# Altium schematic sheet 3-Fuses.SchDoc(3-Fuses)
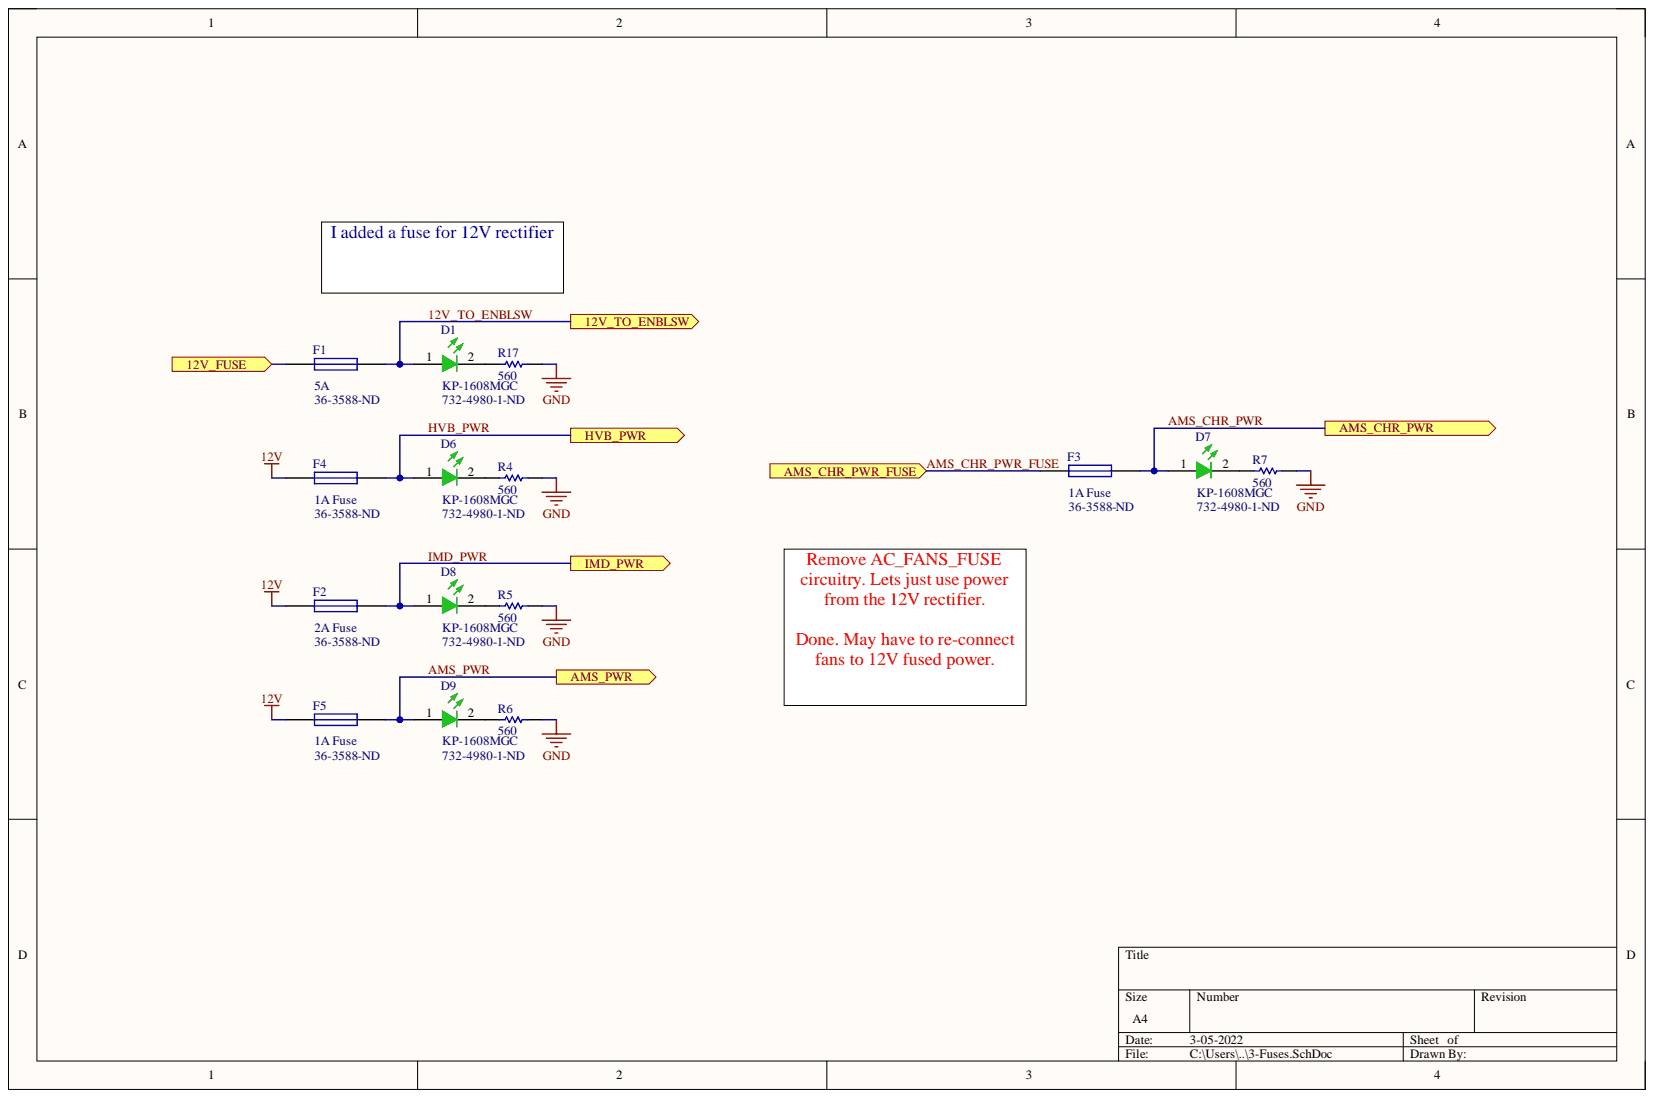
[diagram p0: 3-Fuses.SchDoc]

## Parts
D1  KP-1608MGC  Supplier Part Number 1: 732-4980-1-ND  [KING-0603LED_GREEN_V]
D6  KP-1608MGC  Supplier Part Number 1: 732-4980-1-ND  [KING-0603LED_GREEN_V]
D7  KP-1608MGC  Supplier Part Number 1: 732-4980-1-ND  [KING-0603LED_GREEN_V]
D8  KP-1608MGC  Supplier Part Number 1: 732-4980-1-ND  [KING-0603LED_GREEN_V]
D9  KP-1608MGC  Supplier Part Number 1: 732-4980-1-ND  [KING-0603LED_GREEN_V]
F1  5A  Supplier Part Number 1: 36-3588-ND  [FUSE 3588 Holder]
F2  2A Fuse  Supplier Part Number 1: 36-3588-ND  [FUSE 3588 Holder]
F3  1A Fuse  Supplier Part Number 1: 36-3588-ND  [FUSE 3588 Holder]
F4  1A Fuse  Supplier Part Number 1: 36-3588-ND  [FUSE 3588 Holder]
F5  1A Fuse  Supplier Part Number 1: 36-3588-ND  [FUSE 3588 Holder]
R4  560  [RESC0603(1608)_L]
R5  560  [RESC0603(1608)_L]
R6  560  [RESC0603(1608)_L]
R7  560  [RESC0603(1608)_L]
R17  560  [RESC0603(1608)_L]

## Ports
12V_FUSE, 12V_TO_ENBLSW, AMS_CHR_PWR, AMS_CHR_PWR_FUSE, AMS_PWR, HVB_PWR, IMD_PWR

## Nets
12V: F2.1 F4.1 F5.1
GND: R4.2 R5.2 R6.2 R7.2 R17.2
12V_FUSE: F1.1 ; port 12V_FUSE
12V_TO_ENBLSW: D1.1 F1.2 ; port 12V_TO_ENBLSW
AMS_CHR_PWR: D7.1 F3.2 ; port AMS_CHR_PWR
AMS_CHR_PWR_FUSE: F3.1 ; port AMS_CHR_PWR_FUSE
AMS_PWR: D9.1 F5.2 ; port AMS_PWR
HVB_PWR: D6.1 F4.2 ; port HVB_PWR
IMD_PWR: D8.1 F2.2 ; port IMD_PWR
NetD1_2: D1.2 R17.1
NetD6_2: D6.2 R4.1
NetD7_2: D7.2 R7.1
NetD8_2: D8.2 R5.1
NetD9_2: D9.2 R6.1

## Library data of the parts
D1 D6-D9 = CMP-0404-00001-1: LED, SMT, 0603(1608), 1.1mm thickness, Green; Manufacturer: Kingbright; Forward Current: 30 mA; Packing Type: 2000 pcs / reel; Power Dissipation: 75 mW; Reverse Voltage: 5 V; Component Kind: Standard; ComponentLink1Description: Manufacturer URL; ComponentLink1URL: http://www.kingbright.com/; Library Name: Altium Content Vault; Mounting Technology: Surface Mount; PackageDescription: 2-Pin SMT LED, 1.6 x 0.8 mm Pitch; PackageReference: 0603LED_GREEN; PartNumber: KP-1608MGC; Storage Temperature: -40 to +85 degC; Supplier 1: Digi-Key
F1-F5 = Fuse 1: Fuse; Component Kind: Standard; LatestRevisionDate: 17-Jul-2002; LatestRevisionNote: Re-released for DXP Platform.; Library Name: Power_PCB.SCHLIB; PackageDescription: Thru-Hole; 2 Leads; PackageReference: PIN-W2/E2.8; Published: 8-Jun-2000; Simulation: FUSE; Supplier 1: Digi-Key; Publisher: Altium Limited
R4-R7 R17 = CMP-1591-00001-1: 0603 (1608 Metric) Chip Resistor; Case-EIA: 0603; Case-Metric: 1608; Component Kind: Standard; Library Name: Altium Content Vault; PackageReference: RESC0603(1608); Supplier 1: Digi-Key; Supplier Part Number 1: P560BZCT-ND
